# Altium schematic sheet [02] Arduino.SchDoc
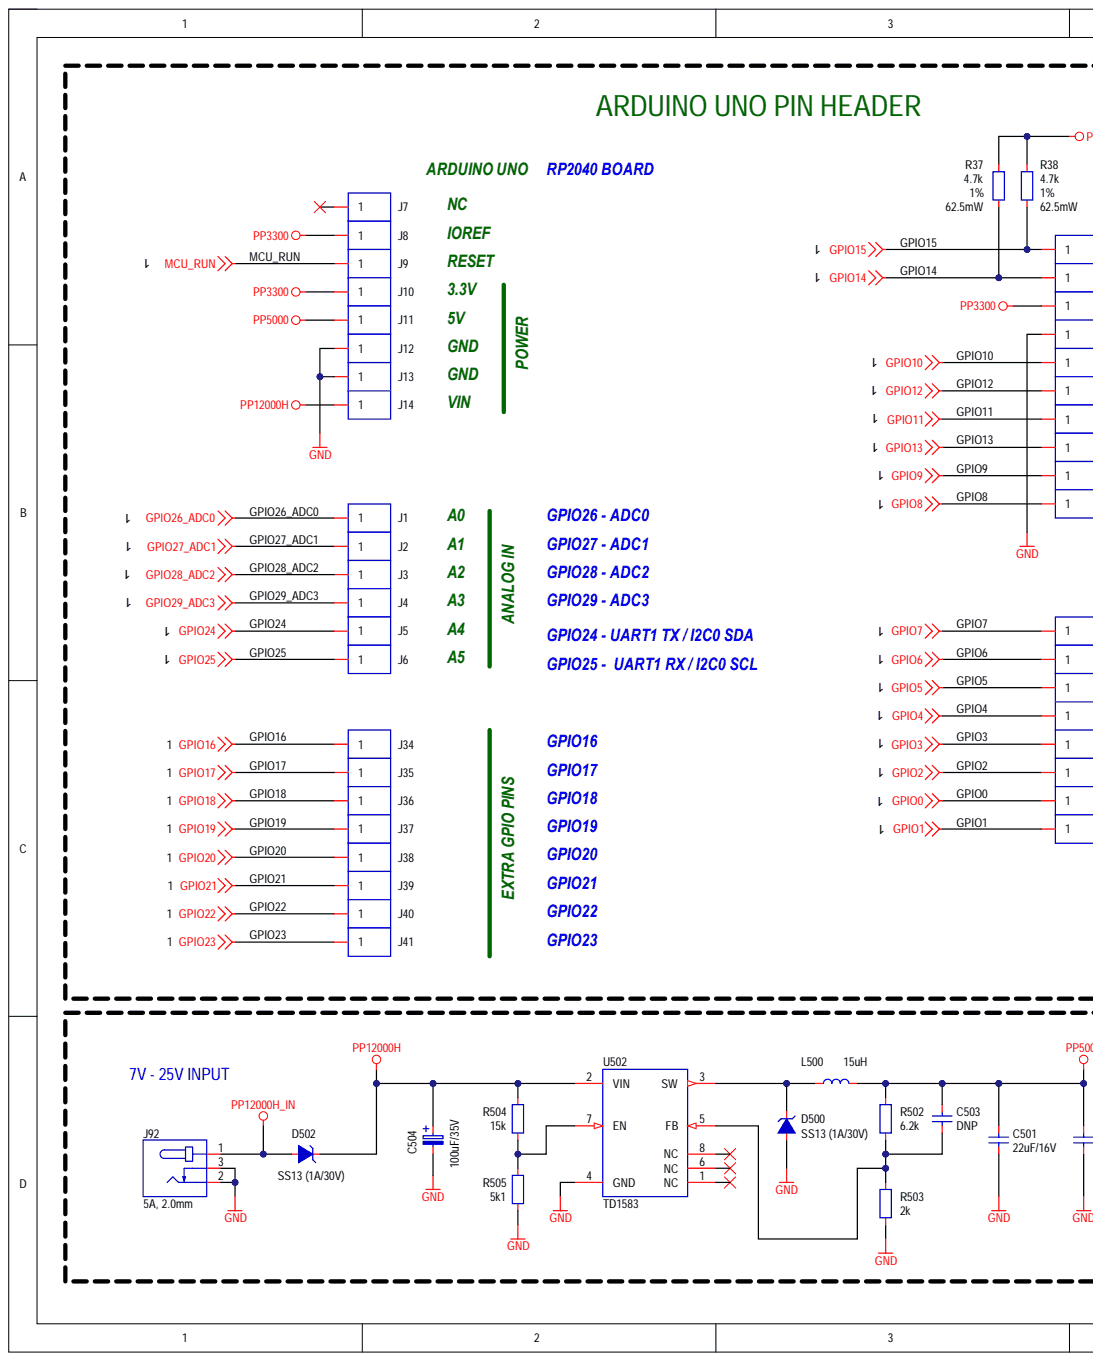
[diagram p0: [02] Arduino.SchDoc, left]
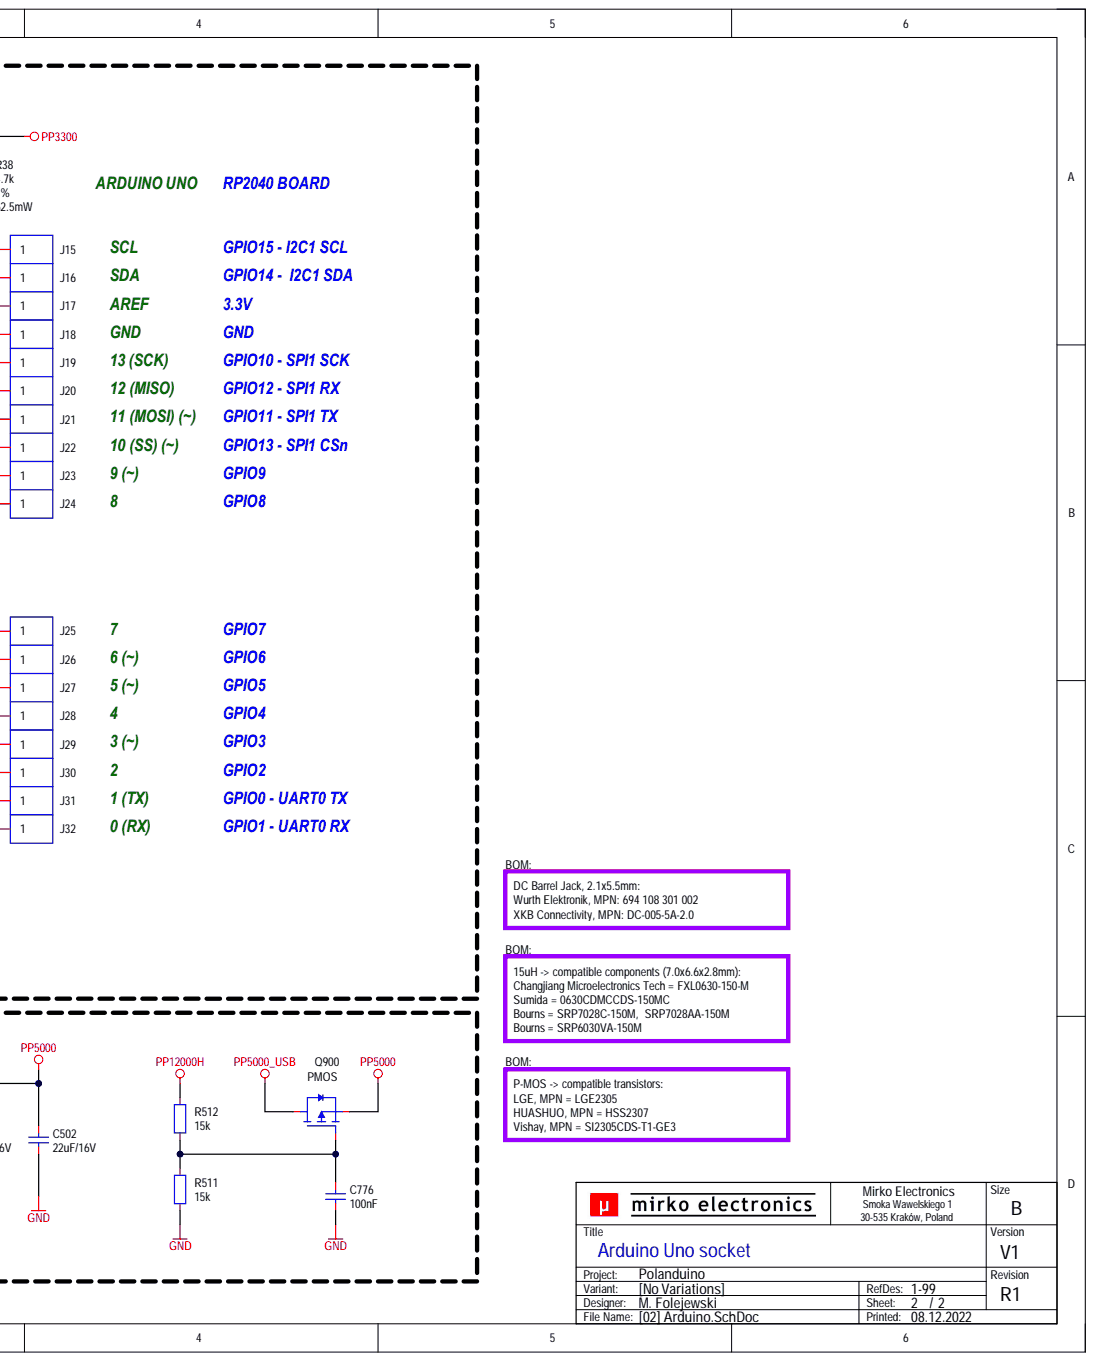
[diagram p1: [02] Arduino.SchDoc, right]

## Parts
C501  22uF/16V  [CAP_0805_R]
C502  22uF/16V  [CAP_0805_R]
C503  DNP  [CAP_0603_R]
C504  100uF/35V  [CAP_SMD_SIZE_D8]
C776  100nF  [CAP_0603_R]
D500  SS13 (1A/30V)  MPN: SS13  [DIO_SMA_UNI_R]
D502  SS13 (1A/30V)  MPN: SS13  [DIO_SMA_UNI_R]
J1  =Value  [PCB_PAD_THT_280X180H100_RND]  (no BOM)
J2  =Value  [PCB_PAD_THT_280X180H100_RND]  (no BOM)
J3  =Value  [PCB_PAD_THT_280X180H100_RND]  (no BOM)
J4  =Value  [PCB_PAD_THT_280X180H100_RND]  (no BOM)
J5  =Value  [PCB_PAD_THT_280X180H100_RND]  (no BOM)
J6  =Value  [PCB_PAD_THT_280X180H100_RND]  (no BOM)
J7  =Value  [PCB_PAD_THT_280X180H100_RND]  (no BOM)
J8  =Value  [PCB_PAD_THT_280X180H100_RND]  (no BOM)
J9  =Value  [PCB_PAD_THT_280X180H100_RND]  (no BOM)
J10  =Value  [PCB_PAD_THT_280X180H100_RND]  (no BOM)
J11  =Value  [PCB_PAD_THT_280X180H100_RND]  (no BOM)
J12  =Value  [PCB_PAD_THT_280X180H100_RND]  (no BOM)
J13  =Value  [PCB_PAD_THT_280X180H100_RND]  (no BOM)
J14  =Value  [PCB_PAD_THT_280X180H100_RND]  (no BOM)
J15  =Value  [PCB_PAD_THT_280X180H100_RND]  (no BOM)
J16  =Value  [PCB_PAD_THT_280X180H100_RND]  (no BOM)
J17  =Value  [PCB_PAD_THT_280X180H100_RND]  (no BOM)
J18  =Value  [PCB_PAD_THT_280X180H100_RND]  (no BOM)
J19  =Value  [PCB_PAD_THT_280X180H100_RND]  (no BOM)
J20  =Value  [PCB_PAD_THT_280X180H100_RND]  (no BOM)
J21  =Value  [PCB_PAD_THT_280X180H100_RND]  (no BOM)
J22  =Value  [PCB_PAD_THT_280X180H100_RND]  (no BOM)
J23  =Value  [PCB_PAD_THT_280X180H100_RND]  (no BOM)
J24  =Value  [PCB_PAD_THT_280X180H100_RND]  (no BOM)
J25  =Value  [PCB_PAD_THT_280X180H100_RND]  (no BOM)
J26  =Value  [PCB_PAD_THT_280X180H100_RND]  (no BOM)
J27  =Value  [PCB_PAD_THT_280X180H100_RND]  (no BOM)
J28  =Value  [PCB_PAD_THT_280X180H100_RND]  (no BOM)
J29  =Value  [PCB_PAD_THT_280X180H100_RND]  (no BOM)
J30  =Value  [PCB_PAD_THT_280X180H100_RND]  (no BOM)
J31  =Value  [PCB_PAD_THT_280X180H100_RND]  (no BOM)
J32  =Value  [PCB_PAD_THT_280X180H100_RND]  (no BOM)
J34  =Value  [PCB_PAD_THT_280X180H100_RND]  (no BOM)
J35  =Value  [PCB_PAD_THT_280X180H100_RND]  (no BOM)
J36  =Value  [PCB_PAD_THT_280X180H100_RND]  (no BOM)
J37  =Value  [PCB_PAD_THT_280X180H100_RND]  (no BOM)
J38  =Value  [PCB_PAD_THT_280X180H100_RND]  (no BOM)
J39  =Value  [PCB_PAD_THT_280X180H100_RND]  (no BOM)
J40  =Value  [PCB_PAD_THT_280X180H100_RND]  (no BOM)
J41  =Value  [PCB_PAD_THT_280X180H100_RND]  (no BOM)
J92  5A, 2.0mm  [CON_WRT_694108301002]
L500  15uH  [IND_SUM_0630CDMCCDS_700X660X280_R]
Q900  PMOS  [PKG_SOT23-3_TO236]
R37  4.7k  Power Rating: 62.5mW  Tolerance: 1%  [RES_0402_R]
R38  4.7k  Power Rating: 62.5mW  Tolerance: 1%  [RES_0402_R]
R502  6.2k  [RES_0603_R]
R503  2k  [RES_0603_R]
R504  15k  [RES_0603_R]
R505  5k1  [RES_0603_R]
R511  15k  [RES_0603_R]
R512  15k  [RES_0603_R]
U502  TD1583  [ICC_SO8_SOP8_490X390X160_P127_R]

## Nets
GND: C501.1 C502.1 C504.1 C776.1 D500.2 J12.1 J13.1 J18.1 J92.2 J92.3 R503.2 R505.2 R511.1 U502.4(GND)
PP3300: J8.1 J10.1 J17.1 R37.2 R38.2
PP5000: C501.2 C502.2 C503.2 J11.1 L500.2 Q900.2 R502.2
PP5000_USB: Q900.3
PP12000H: C504.2 D502.1 J14.1 R504.2 R512.2 U502.2(VIN)
PP12000H_IN: D502.2 J92.1
GPIO0: J31.1
GPIO1: J32.1
GPIO2: J30.1
GPIO3: J29.1
GPIO4: J28.1
GPIO5: J27.1
GPIO6: J26.1
GPIO7: J25.1
GPIO8: J24.1
GPIO9: J23.1
GPIO10: J19.1
GPIO11: J21.1
GPIO12: J20.1
GPIO13: J22.1
GPIO14: J16.1 R37.1
GPIO15: J15.1 R38.1
GPIO16: J34.1
GPIO17: J35.1
GPIO18: J36.1
GPIO19: J37.1
GPIO20: J38.1
GPIO21: J39.1
GPIO22: J40.1
GPIO23: J41.1
GPIO24: J5.1
GPIO25: J6.1
GPIO26_ADC0: J1.1
GPIO27_ADC1: J2.1
GPIO28_ADC2: J3.1
GPIO29_ADC3: J4.1
MCU_RUN: J9.1
NetC503_1: C503.1 R502.1 R503.1 U502.5(FB)
NetC776_2: C776.2 Q900.1 R511.2 R512.1
NetD500_1: D500.1 L500.1 U502.3(SW)
NetR504_1: R504.1 R505.1 U502.7(EN)

Not connected: J7 1; U502 1(NC) 6(NC) 8(NC)

## Library data of the parts
C501 C502 = CAP-0024: Capacitor; MLCC; GENERIC; TBD; TBD; TBD; TBD; SMD; 0805 (2012);; Database Table Name: CAPACITOR$; Capacitance: GENERIC; Component Body: TBD; Component Height: TBD; Component Kind: Standard; Component Subtype: MLCC; Component Type: Capacitor; Dielectric: TBD; Features: TBD; ID: CAP-0024; Manufacturer: GENERIC; Mounting Type: SMD; MPN: GENERIC; Operating Temperature: TBD; Package (Case): 0805 (2012); Packaging Type: TBD; ROHS Status: TBD; Supplier: ?; Tolerance: TBD; Value: 22uF/16V; Voltage Rating: TBD; Library Name: MirFol_db_local.DbLib
C503 = CAP-0018: Capacitor; MLCC; DNP; TBD; TBD; TBD; DO NOT PLACE; SMD; 0603 (1608);; Database Table Name: CAPACITOR$; Capacitance: DNP; Component Body: TBD; Component Height: TBD; Component Kind: Standard; Component Subtype: MLCC; Component Type: Capacitor; Dielectric: TBD; Features: DO NOT PLACE; ID: CAP-0018; Manufacturer: DNP; Mounting Type: SMD; MPN: DNP; Operating Temperature: TBD; Package (Case): 0603 (1608); Packaging Type: TBD; ROHS Status: TBD; Tolerance: TBD; Value: DNP; Voltage Rating: TBD; Library Name: MirFol_db_local.DbLib
C504 = CAP_POL_2P_NP: Capacitor; Polarized;; Manufacturer: Panasonic; MPN: EEE-TQV101XAP; Component Subtype: Electrolytic; Package (Case): SMD SIZE D8; Component Kind: Standard; Component Type: Capacitor; Mounting Type: SMD; Value: 100uF/35V; Library Name: MirFol_lib.SchLib
C776 = CAP-0008: Capacitor; MLCC; 100nF; TBD; TBD; TBD; TBD; SMD; 0603 (1608);; Database Table Name: CAPACITOR$; Component Kind: Standard; Component Subtype: MLCC; Component Type: Capacitor; Manufacturer: TBD; Mounting Type: SMD; MPN: TBD; Package (Case): 0603 (1608); Supplier: ?; Value: 100nF; Library Name: MirFol_db_local.DbLib
D500 D502 = DIO_TVS_UNI_KA: Diode; Schottky; Unipolar;; Manufacturer: ON Semi; Component Subtype: TVS Diode; Package (Case): DO-214AC (SMA); Component Kind: Standard; Component Type: Diode; Mounting Type: SMD; Supplier: LCSC; Value: SS13 (1A/30V); Library Name: MirFol_lib.SchLib
J1-J32 J34-J41 = CON_1X01_COL: Connector; 1 Row;; Library Name: MirFol_lib.SchLib
  J34-J41: Supplier 1: LCSC; Supplier 2: Farnell; Supplier 3: Mouser
J92 = CON_XKB_DC-005-5A-2.0: Connector; DC Barrel Jack;; Manufacturer: XKB Connectivity; Package (Case): THT; Component Kind: Standard; Component Subtype: DC Barrel Jack; Component Type: Connector; Mounting Type: THT; MPN: DC-005-5A-2.0; Supplier: LCSC; Value: 5A, 2.0mm; Library Name: Pineberry_Lib.SchLib
L500 = IND: Inductor;; Manufacturer: Changjiang Microelectronics Tech; MPN: FXL0630-150-M; Component Subtype: Power Inductor; Package (Case): SMD; Component Kind: Standard; Component Type: Inductor; Mounting Type: SMD; Supplier: ?; Value: 15uH; Library Name: MirFol_lib.SchLib
Q900 = TRA_PMOS_GSD: Transistor; PMOS;; Manufacturer: Vishay; MPN: SI2305CDS-T1-GE3; Component Subtype: P-MOSFET; Component Kind: Standard; Component Type: Transistor; Mounting Type: SMD; Supplier: ?; Value: PMOS; Library Name: MirFol_lib.SchLib
R37 R38 = RES-0019: Resistor; Thick Film; 4.7k; 1%; 62.5mW; 100PPM; 50V; Standard; SMD; 0402 (1005);; Manufacturer: Yageo Corporation; MPN: RC0402FR-074K7L; Component Body: 1.00 x 0.50mm; Database Table Name: RESISTOR$; Packaging Type: Tape & Reel; Component Height: 0.35mm; Component Kind: Standard; Component Subtype: Thick Film; Component Type: Resistor; Features: Standard; ID: RES-0019; Mounting Type: SMD; Operating Temperature: -55°C to +155°C; Package (Case): 0402 (1005); Resistance: 4.7k; ROHS Status: RoHS Compliant; Supplier 1: LCSC; Supplier 2: Farnell; Supplier 3: Mouser; Supplier Part Number 1: C105871; Supplier Part Number 2: 9239316; Supplier Part Number 3: 603-RC0402FR-074K7L; TCR: 100PPM; Value: 4.7k; Voltage Rating: 50V; Library Name: MirFol_db_local.DbLib
R502 = RES-0054: Resistor; Thick Film; GENERIC; TBD; TBD; TBD; TBD; TBD; SMD; 0603 (1608);; Component Body: 1.60 x 0.80mm; Database Table Name: RESISTOR$; Resistance: 20k; Component Height: TBD; Component Kind: Standard; Component Subtype: Thick Film; Component Type: Resistor; Features: TBD; ID: RES-0054; Manufacturer: GENERIC; Mounting Type: SMD; MPN: GENERIC; Operating Temperature: TBD; Package (Case): 0603 (1608); Packaging Type: TBD; Power Rating: TBD; ROHS Status: TBD; TCR: TBD; Tolerance: TBD; Value: 6.2k; Voltage Rating: TBD; Library Name: MirFol_db_local.DbLib
R503 = RES-0033: Resistor; Thick Film; 2k2; 1%; 100mW; 100PPM; 75V; Standard; SMD; 0603 (1608);; Manufacturer: Yageo Corporation; MPN: TBD; Database Table Name: RESISTOR$; Component Kind: Standard; Component Subtype: Thick Film; Component Type: Resistor; Mounting Type: SMD; Package (Case): 0603 (1608); Supplier: ?; Value: 2k; Library Name: MirFol_db_local.DbLib
R504 R511 R512 = RES-0043: Resistor; Thick Film; 15k; 1%; 100mW; 100PPM; 75V; Standard; SMD; 0603 (1608);; Manufacturer: Yageo Corporation; MPN: TBD; Database Table Name: RESISTOR$; Component Kind: Standard; Component Subtype: Thick Film; Component Type: Resistor; Mounting Type: SMD; Package (Case): 0603 (1608); Supplier: ?; Value: 15k; Library Name: MirFol_db_local.DbLib
R505 = RES-0043: Resistor; Thick Film; 5k1; 1%; 100mW; 100PPM; 75V; Standard; SMD; 0603 (1608);; Manufacturer: Yageo Corporation; MPN: TBD; Database Table Name: RESISTOR$; Component Kind: Standard; Component Subtype: Thick Film; Component Type: Resistor; Mounting Type: SMD; Package (Case): 0603 (1608); Supplier: ?; Value: 5k1; Library Name: MirFol_db_local.DbLib
U502 = ICC_TCH_TD1583_SOP8: IC; Buck regulator;; Manufacturer: Techcode Semicon; MPN: TD1583PR; Package (Case): SOP8; Specification: Buck converter, 380kHz, 3A, SOP-8 package; Component Kind: Standard; Component Type: IC; Mounting Type: SMD; Value: TD1583; Library Name: Pineberry_Lib.SchLib
